# Altium schematic sheet PWR_FPGA.SchDoc(PWR_FPGA)
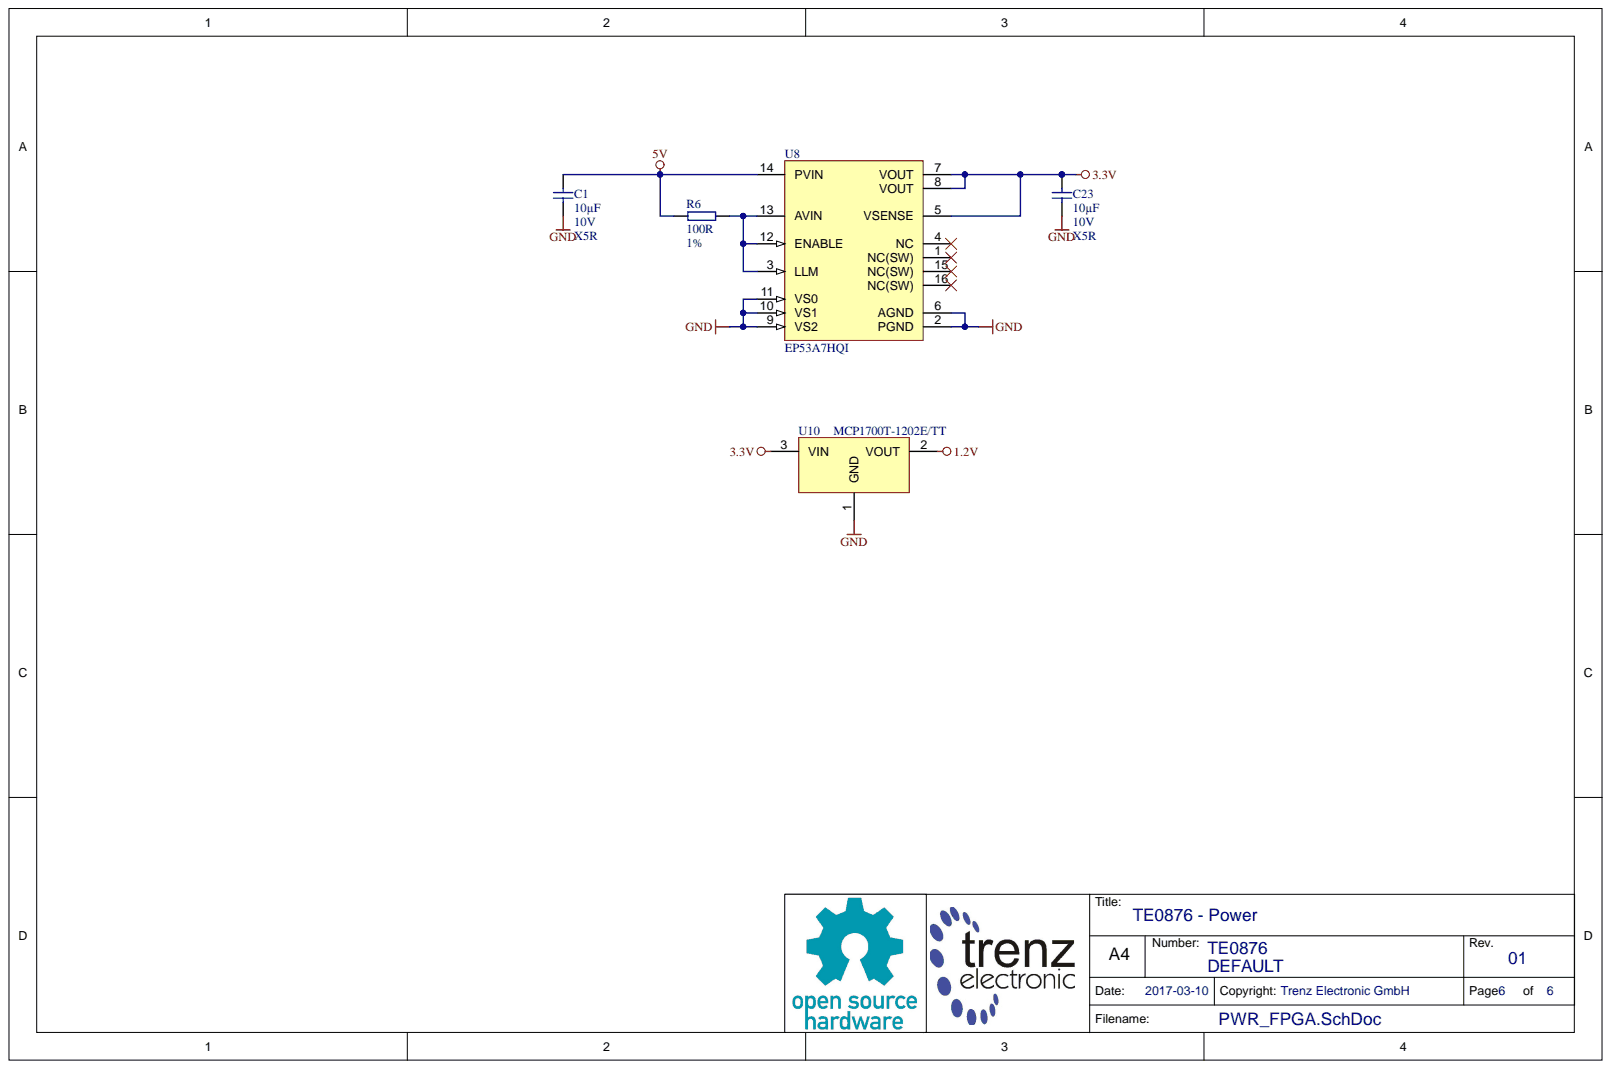
[diagram p0: PWR_FPGA.SchDoc]

## Parts
C1  10µF  Technology: X5R  Voltage: 10V  [CAPC2012X135N]
C23  10µF  Technology: X5R  Voltage: 10V  [CAPC2012X135N]
R6  100R  Tolerance: 1%  [RESC1005X40N]
U8  EP53A7HQI  [EP53F8QI]
U10  MCP1700T-1202E/TT  [SOT95P237X112-3N]

## Nets
1.2V: U10.2
3.3V: C23.1 U8.5(VSENSE) U8.7(VOUT) U8.8(VOUT) U10.3
5V: C1.1 R6.1 U8.14(PVIN)
GND: C1.2 C23.2 U8.2(PGND) U8.6(AGND) U8.9(VS2) U8.10(VS1) U8.11(VS0) U10.1
NetR6_2: R6.2 U8.3(LLM) U8.12(ENABLE) U8.13(AVIN)

Not connected: U8 1(NC(SW)) 4(NC) 15(NC(SW)) 16(NC(SW))

## Library data of the parts
C1 C23 = 28014: KON 10µF 10V X5R 10% SMD; Database Table Name: C_2012_0805; Mixed: SMT; Value: 10u; AN: 28014; Case: 2012 (0805); Component Kind: Standard; Library Name: TE.DbLib; Tolerance: 10%
R6 = 22507: WID SMD 100R 1% 0,063W; Database Table Name: R_1005_0402; Mixed: SMT; Preferred: Yes; Value: 100; AN: 22507; Case: 1005 (0402); Component Kind: Standard; Library Name: TE.DbLib; Technology: TK100
U8 = 27996: Database Table Name: DCDC; Mixed: SMT; Preferred: Yes; AN: 27996; Case: QFN16; Component Kind: Standard; Description: EP53A7HQI; H-ART: EP53A7HQI; Library Name: TE.DbLib; Trenz-Stock: Yes; HelpURL: B:\AD\adlib\datasheet\EP53A7HQI
U10 = 26755: Database Table Name: LREG; Mixed: SMT; Trenz-Stock: Yes; AN: 26755; Case: SOT23-3; Component Kind: Standard; Description: MCP1700T-1202E/TT; H-ART: MCP1700T-1202E/TT; Library Name: TE.DbLib; HelpURL: B:\ad\adlib\datasheet\MCP1700
